# Altium schematic sheet MCU_Control.SchDoc
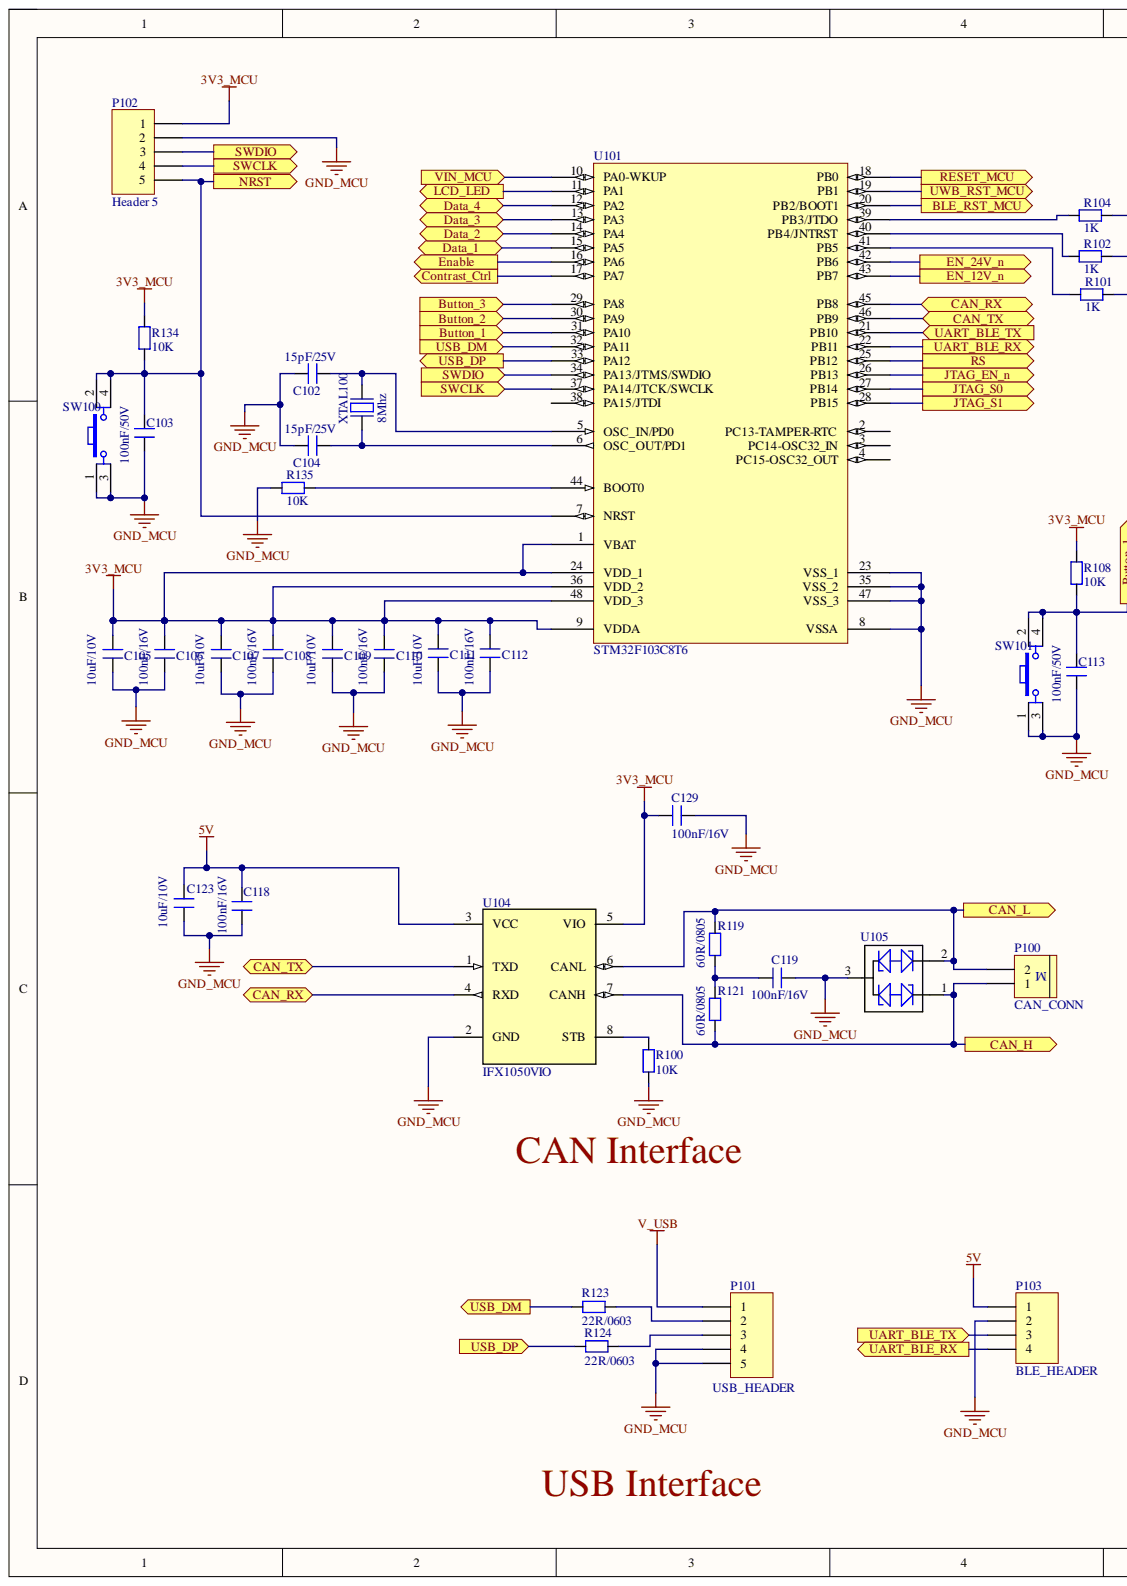
[diagram p0: MCU_Control.SchDoc, left]
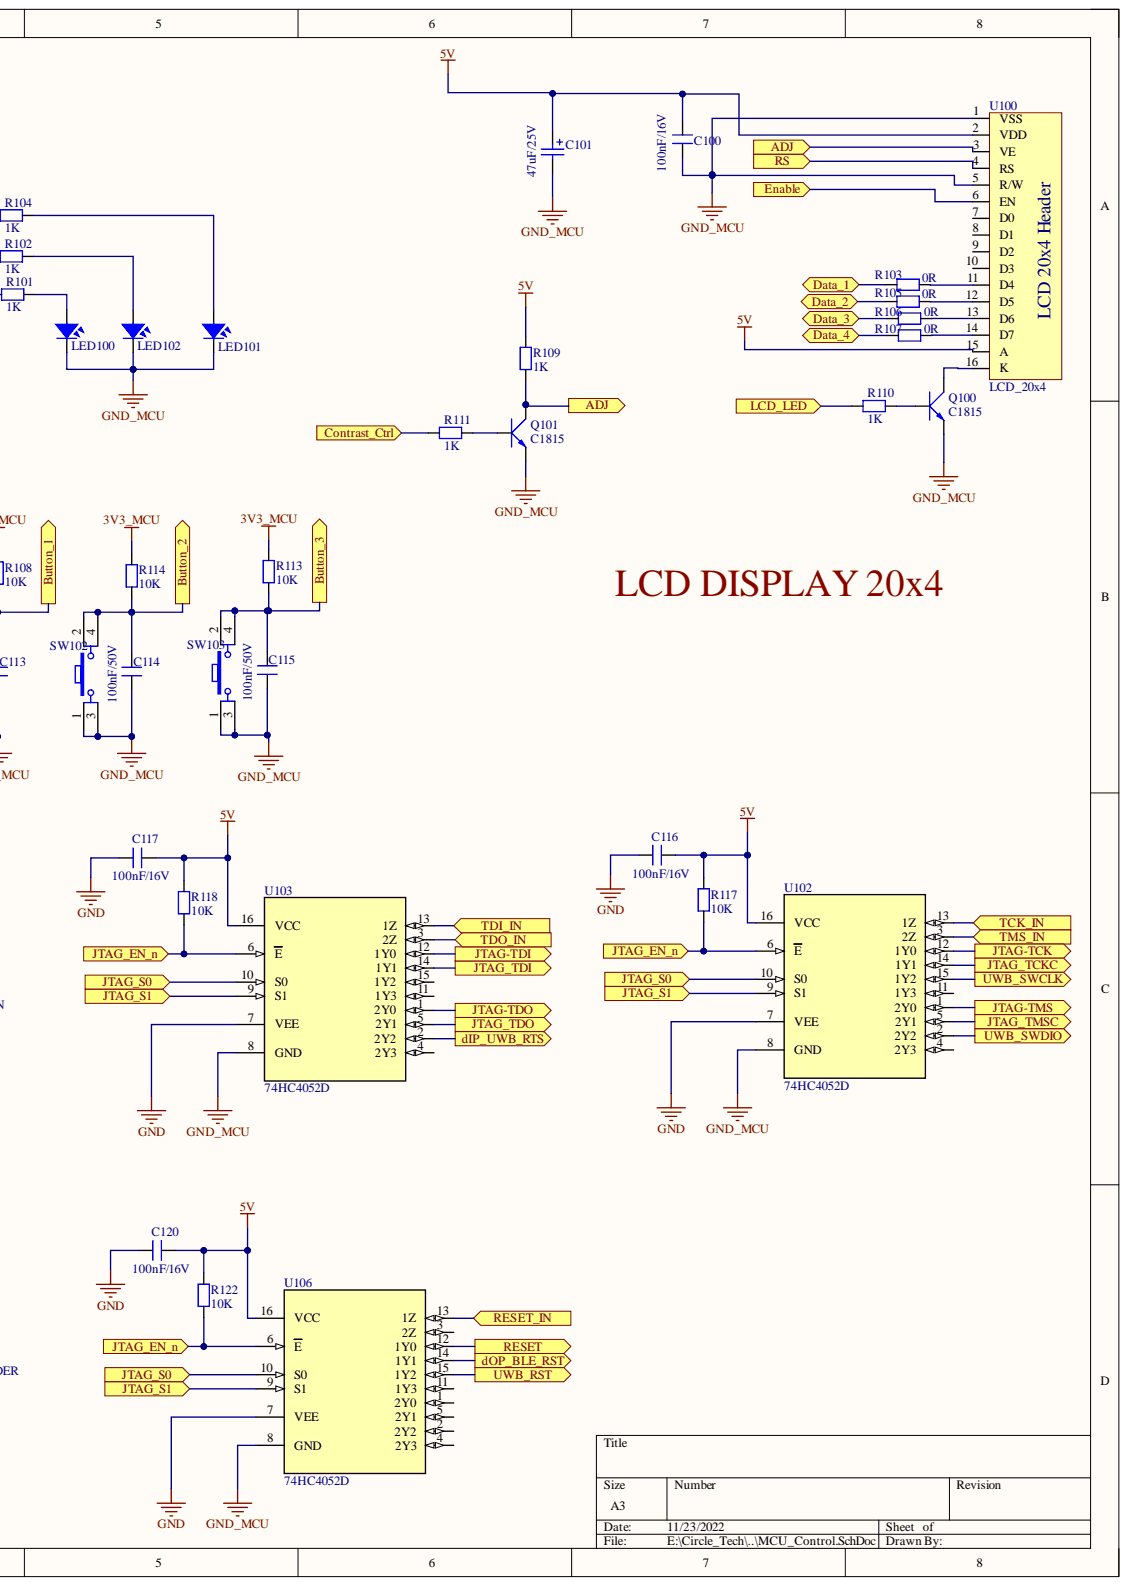
[diagram p1: MCU_Control.SchDoc, right]

## Parts
C100  value 100nF/16V  [C0603]  (hidden comment: C0603_X5R_±10%)
C101  47uF/25V  [SMD_ALU_CAP_D6.3H5.5]
C102  value 15pF/25V  [C0603]  (hidden comment: C0603_X5R_±10%)
C103  value 100nF/50V  [C0603]  (hidden comment: C0603_X5R_±10%)
C104  value 15pF/25V  [C0603]  (hidden comment: C0603_X5R_±10%)
C105  value 10uF/10V  [C0805]  (hidden comment: C0805 X5R ±10%)
C106  value 100nF/16V  [C0603]  (hidden comment: C0603_X5R_±10%)
C107  value 10uF/10V  [C0805]  (hidden comment: C0805 X5R ±10%)
C108  value 100nF/16V  [C0603]  (hidden comment: C0603_X5R_±10%)
C109  value 10uF/10V  [C0805]  (hidden comment: C0805 X5R ±10%)
C110  value 100nF/16V  [C0603]  (hidden comment: C0603_X5R_±10%)
C111  value 10uF/10V  [C0805]  (hidden comment: C0805 X5R ±10%)
C112  value 100nF/16V  [C0603]  (hidden comment: C0603_X5R_±10%)
C113  value 100nF/50V  [C0603]  (hidden comment: C0603_X5R_±10%)
C114  value 100nF/50V  [C0603]  (hidden comment: C0603_X5R_±10%)
C115  value 100nF/50V  [C0603]  (hidden comment: C0603_X5R_±10%)
C116  value 100nF/16V  [C0603]  (hidden comment: C0603_X5R_±10%)
C117  value 100nF/16V  [C0603]  (hidden comment: C0603_X5R_±10%)
C118  value 100nF/16V  [C0603]  (hidden comment: C0603_X5R_±10%)
C119  value 100nF/16V  [C0603]  (hidden comment: C0603_X5R_±10%)
C120  value 100nF/16V  [C0603]  (hidden comment: C0603_X5R_±10%)
C123  value 10uF/10V  [C0805]  (hidden comment: C0805 X5R ±10%)
C129  value 100nF/16V  [C0603]  (hidden comment: C0603_X5R_±10%)
LED100  LED SMD  [SM-LED-0805-Red]  (value not drawn)
LED101  LED SMD  [SM-LED-0805-Blue]  (value not drawn)
LED102  LED SMD  [SM-LED-0805-Green]  (value not drawn)
P100  CAN_CONN  [Medium - Header 2]
P101  USB_HEADER  [HDR1X5]
P102  Header 5  [HDR1X5]
P103  BLE_HEADER  [HDR1X4]
Q100  C1815  [SOT23B]
Q101  C1815  [SOT23B]
R100  value 10K  [R0603]  (hidden comment: Value)
R101  value 1K  [R0603]  (hidden comment: Value)
R102  value 1K  [R0603]  (hidden comment: Value)
R103  value 0R  [R0603]  (hidden comment: Value)
R104  value 1K  [R0603]  (hidden comment: Value)
R105  value 0R  [R0603]  (hidden comment: Value)
R106  value 0R  [R0603]  (hidden comment: Value)
R107  value 0R  [R0603]  (hidden comment: Value)
R108  value 10K  [R0603]  (hidden comment: Value)
R109  value 1K  [R0603]  (hidden comment: Value)
R110  value 1K  [R0603]  (hidden comment: Value)
R111  value 1K  [R0603]  (hidden comment: Value)
R113  value 10K  [R0603]  (hidden comment: Value)
R114  value 10K  [R0603]  (hidden comment: Value)
R117  value 10K  [R0603]  (hidden comment: Value)
R118  value 10K  [R0603]  (hidden comment: Value)
R119  60R/0805  [R0805]
R121  60R/0805  [R0805]
R122  value 10K  [R0603]  (hidden comment: Value)
R123  value 22R/0603  [R0603]
R124  value 22R/0603  [R0603]
R134  value 10K  [R0603]  (hidden comment: Value)
R135  value 10K  [R0603]  (hidden comment: Value)
SW100  SW  [SW4]
SW101  SW  [SW4]
SW102  SW  [SW4]
SW103  SW  [SW4]
U100  LCD_20x4  [LCD_20x4]
U101  STM32F103C8T6  [LQFP48_L]
U102  74HC4052D  [P-SOIC-16]
U103  74HC4052D  [P-SOIC-16]
U104  IFX1050VIO  [SOIC-8]
U105  Value  [SOT23]  (value not drawn)
U106  74HC4052D  [P-SOIC-16]
XTAL100  8Mhz  [Crystal_3225]

## Ports
ADJ, BLE_RST_MCU, Button_1, Button_2, Button_3, CAN_H, CAN_L, CAN_RX, CAN_TX, Contrast_Ctrl, Data_1, Data_2, Data_3, Data_4, dIP_UWB_RTS, dOP_BLE_RST, EN_12V_n, EN_24V_n, Enable, JTAG-TCK, JTAG-TDI, JTAG-TDO, JTAG-TMS, JTAG_EN_n, JTAG_S0, JTAG_S1, JTAG_TCKC, JTAG_TDI, JTAG_TDO, JTAG_TMSC, LCD_LED, NRST, RESET, RESET_IN, RESET_MCU, RS, SWCLK, SWDIO, TCK_IN, TDI_IN, TDO_IN, TMS_IN, UART_BLE_RX, UART_BLE_TX, USB_DM, USB_DP, UWB_RST, UWB_RST_MCU, UWB_SWCLK, UWB_SWDIO, VIN_MCU

## Nets
3V3_MCU: C105.2 C106.2 C107.2 C108.2 C109.2 C110.2 C111.2 C112.2 C129.2 P102.1 R108.2 R113.2 R114.2 R134.2 U101.1(VBAT) U101.9(VDDA) U101.24(VDD_1) U101.36(VDD_2) U101.48(VDD_3) U104.5(VIO)
5V: C100.2 C101.1 C116.1 C117.1 C118.2 C120.1 C123.2 P103.1 R109.2 R117.2 R118.2 R122.2 U100.2(VDD) U100.15(A) U102.16(VCC) U103.16(VCC) U104.3(VCC) U106.16(VCC)
GND: C116.2 C117.2 C120.2 U102.7(VEE) U103.7(VEE) U106.7(VEE)
GND_MCU: C100.1 C101.2 C102.2 C103.1 C104.2 C105.1 C106.1 C107.1 C108.1 C109.1 C110.1 C111.1 C112.1 C113.1 C114.1 C115.1 C118.1 C119.1 C123.1 C129.1 LED100.2 LED101.2 LED102.2 P101.4 P101.5 P102.2 P103.2 Q100.1 Q101.1 R100.1 R135.1 SW100.1 SW100.3 SW101.1 SW101.3 SW102.1 SW102.3 SW103.1 SW103.3 U100.1(VSS) U100.5(R/W) U101.8(VSSA) U101.23(VSS_1) U101.35(VSS_2) U101.47(VSS_3) U102.8(GND) U103.8(GND) U104.2(GND) U105.3 U106.8(GND)
V_USB: P101.1
ADJ: Q101.2 R109.1 U100.3(VE) ; port ADJ
BLE_RST_MCU: U101.20(PB2/BOOT1) ; port BLE_RST_MCU
Button_1: C113.2 R108.1 SW101.2 SW101.4 U101.31(PA10) ; port Button_1
Button_2: C114.2 R114.1 SW102.2 SW102.4 U101.30(PA9) ; port Button_2
Button_3: C115.2 R113.1 SW103.2 SW103.4 U101.29(PA8) ; port Button_3
CAN_H: P100.1 R121.1 U104.7(CANH) U105.1 ; port CAN_H
CAN_L: P100.2 R119.2 U104.6(CANL) U105.2 ; port CAN_L
CAN_RX: U101.45(PB8) U104.4(RXD) ; port CAN_RX
CAN_TX: U101.46(PB9) U104.1(TXD) ; port CAN_TX
Contrast_Ctrl: R111.1 U101.17(PA7) ; port Contrast_Ctrl
Data_1: R103.1 U101.15(PA5) ; port Data_1
Data_2: R105.1 U101.14(PA4) ; port Data_2
Data_3: R106.1 U101.13(PA3) ; port Data_3
Data_4: R107.1 U101.12(PA2) ; port Data_4
dIP_UWB_RTS: U103.2(2Y2) ; port dIP_UWB_RTS
dOP_BLE_RST: U106.14(1Y1) ; port dOP_BLE_RST
EN_12V_n: U101.43(PB7) ; port EN_12V_n
EN_24V_n: U101.42(PB6) ; port EN_24V_n
Enable: U100.6(EN) U101.16(PA6) ; port Enable
JTAG-TCK: U102.12(1Y0) ; port JTAG-TCK
JTAG-TMS: U102.1(2Y0) ; port JTAG-TMS
JTAG_EN_n: R117.1 R118.1 R122.1 U101.26(PB13) U102.6(E) U103.6(E) U106.6(E) ; port JTAG_EN_n
JTAG_S0: U101.27(PB14) U102.10(S0) U103.10(S0) U106.10(S0) ; port JTAG_S0
JTAG_S1: U101.28(PB15) U102.9(S1) U103.9(S1) U106.9(S1) ; port JTAG_S1
JTAG_TCKC: U102.14(1Y1) ; port JTAG_TCKC
JTAG_TDO: U103.5(2Y1) ; port JTAG_TDO
JTAG_TMSC: U102.5(2Y1) ; port JTAG_TMSC
LCD_LED: R110.1 U101.11(PA1) ; port LCD_LED
NRST: C103.2 P102.5 R134.1 SW100.2 SW100.4 U101.7(NRST) ; port NRST
RESET: U106.12(1Y0) ; port RESET
RESET_IN: U106.13(1Z) ; port RESET_IN
RESET_MCU: U101.18(PB0) ; port RESET_MCU
RS: U100.4(RS) U101.25(PB12) ; port RS
SWCLK: P102.4 U101.37(PA14/JTCK/SWCLK) ; port SWCLK
SWDIO: P102.3 U101.34(PA13/JTMS/SWDIO) ; port SWDIO
TCK_IN: U102.13(1Z) ; port TCK_IN
TDI_IN: U103.13(1Z) ; port TDI_IN
TDO_IN: U103.3(2Z) ; port TDO_IN
TMS_IN: U102.3(2Z) ; port TMS_IN
UART_BLE_RX: P103.4 U101.22(PB11) ; port UART_BLE_RX
UART_BLE_TX: P103.3 U101.21(PB10) ; port UART_BLE_TX
USB_DM: R123.1 U101.32(PA11) ; port USB_DM
USB_DP: R124.1 U101.33(PA12) ; port USB_DP
UWB_RST: U106.15(1Y2) ; port UWB_RST
UWB_RST_MCU: U101.19(PB1) ; port UWB_RST_MCU
UWB_SWCLK: U102.15(1Y2) ; port UWB_SWCLK
UWB_SWDIO: U102.2(2Y2) ; port UWB_SWDIO
VIN_MCU: U101.10(PA0-WKUP) ; port VIN_MCU
NetC102_1: C102.1 U101.5(OSC_IN/PD0) XTAL100.3
NetC104_1: C104.1 U101.6(OSC_OUT/PD1) XTAL100.1
NetC119_2: C119.2 R119.1 R121.2
NetLED100_1: LED100.1 R101.2
NetLED101_1: LED101.1 R104.2
NetLED102_1: LED102.1 R102.2
NetP101_2: P101.2 R123.2
NetP101_3: P101.3 R124.2
NetQ100_2: Q100.2 U100.16(K)
NetQ100_3: Q100.3 R110.2
NetQ101_3: Q101.3 R111.2
NetR100_2: R100.2 U104.8(STB)
NetR101_1: R101.1 U101.41(PB5)
NetR102_1: R102.1 U101.40(PB4/JNTRST)
NetR103_2: R103.2 U100.11(D4)
NetR104_1: R104.1 U101.39(PB3/JTDO)
NetR105_2: R105.2 U100.12(D5)
NetR106_2: R106.2 U100.13(D6)
NetR107_2: R107.2 U100.14(D7)
NetR135_2: R135.2 U101.44(BOOT0)
NetU103_1: U103.1(2Y0)
NetU103_12: U103.12(1Y0)
NetU103_14: U103.14(1Y1)

Not connected: U100 7(D0) 8(D1) 9(D2) 10(D3); U101 2(PC13-TAMPER-RTC) 3(PC14-OSC32_IN) 4(PC15-OSC32_OUT) 38(PA15/JTDI); U102 4(2Y3) 11(1Y3); U103 4(2Y3) 11(1Y3) 15(1Y2); U106 1(2Y0) 2(2Y2) 3(2Z) 4(2Y3) 5(2Y1) 11(1Y3)

## Library data of the parts
C100 C102-C104 C106 C108 C110 C112-C120 C129 = C0603_X5R_±10%: SMD Ceramic Capacitor 0603 X5R ±10%; Component Kind: Standard; Component Type: Passive; Package: C0603; Library Name: Daniel_Lib.IntLib
C101 = SMD_ALU_CAP: SMD Aluminum Electrolytic Capacitor; Manufacturer: Wurth Elektronik; Manufacturer Part Number: 865230140001; Capacitance: 22uF; Capacitance Tolerance: +/-20%; Dissipation Factor: 32%; Endurance: 5000h; Leakage Current: 3uA; Rated Voltage: 6.3VDC; Ripple Current: 22mA; Technical Reference: ASDB055220M6R3DVCTAE000; Component Kind: Standard; ComponentLink1Description: Manufacturer; ComponentLink1URL: http://www.we-online.de; ComponentLink2Description: Datasheet; ComponentLink2URL: https://www.we-online.com/catalog/datasheet/865230140001.pdf; Diameter: 4mm; Height: 5.5mm; Mounting Technology: SMT; Operating Temperature: -40 to +105degC; Packaging: Tape and Reel; Published Date: October 2020; Library Name: Daniel_Lib.IntLib
C105 C107 C109 C111 C123 = C0805 X5R ±10%: SMD Ceramic Capacitor 0805 X5R ±10%; Component Kind: Standard; Component Type: Passive; Package: C0805; Library Name: Daniel_Lib.IntLib
LED100-LED102 = LED-SMD-0805: Component Kind: Standard; Library Name: syhaunguyen.IntLib
P100 = Header 2 - M: Header, 2-Pin, Medium; Component Kind: Standard; LatestRevisionDate: 17-Jul-2002; LatestRevisionNote: Re-released for DXP Platform.; Library Name: NBBLib 14-03-2012.IntLib; Signal Integrity: Connector; Publisher: Altium Limited
P101 P102 = Header 5: Header, 5-Pin; Component Kind: Standard; LatestRevisionDate: 17-Jul-2002; LatestRevisionNote: Re-released for DXP Platform.; Library Name: NBBLib 14-03-2012.IntLib; Signal Integrity: Connector; Publisher: Altium Limited
P103 = Header 4: Header, 4-Pin; Component Kind: Standard; LatestRevisionDate: 17-Jul-2002; LatestRevisionNote: Re-released for DXP Platform.; Library Name: NBBLib 14-03-2012.IntLib; Signal Integrity: Connector; Publisher: Altium Limited
Q100 Q101 = C1815: NPN 600mW, ECB; Component Kind: Standard; Value: C1815; Library Name: syhaunguyen.IntLib
R100-R111 R113 R114 R117 R118 R122-R124 R134 R135 = R0603: SMD Resistor 0603 0.1W 1%; Manufacturer: Uniohm; Component Kind: Standard; Component Type: Passive; Package: R0603; Library Name: Daniel_Lib.IntLib
R119 R121 = R0805: Resistor SMD 0805 0.125W; Component Kind: Standard; Library Name: Daniel_Lib.IntLib
SW100-SW103 = SW4: Switch button 4 pin; Value: Button chip 4 pin; Component Kind: Standard; Library Name: syhaunguyen.IntLib
U100 = LCD_20x4: LCD 20x4; Component Kind: Standard; Library Name: Daniel_Lib.IntLib
U101 = STM32F103C8T6: STM32 ARM-based 32-bit MCU with 64 Kbytes Flash, 48-pin LQFP, Industrial Temperature; Component Kind: Standard; Library Name: TrieuLIB.IntLib
U102 U103 U106 = 74HC4052D: 2 Circuit IC Switch 4:1 150Ohm 16-SO; MF: Nexperia USA; MP: 74HC4052D,652; Availability: Good; Component Kind: Standard; DigiKey-Purchase-URL: https://snapeda.com/shop?store=DigiKey&id=12544; Library Name: IC.IntLib; Mouser-Purchase-URL: https://snapeda.com/shop?store=Mouser&id=12544; Package: SOIC-16 NXP Semiconductors; Price: None; SnapEDA_Link: https://www.snapeda.com/parts/74HC4052D,652/Nexperia%20USA%20Inc./view-part/12544/?ref=snap
U104 = IFX1050VIO: 1/1 Transceiver Half CANbus 8-SO; MF: NXP USA; MP: TJA1040; Availability: Unavailable; Component Kind: Standard; Library Name: IC.IntLib; Package: SOIC-8 NXP USA; Price: None; SnapEDA_Link: https://www.snapeda.com/parts/TJA1040/NXP%20USA%20Inc./view-part/563351/?ref=snap
U105 = PESD_SOT23: Low capacitance double bidirectional ESD protection diodes  in SOT23; Component Kind: Standard; Library Name: Daniel_Lib.IntLib
XTAL100 = Crystal_3225_SMD: Crystal SMD 3225; MF: Vishay Vitramon; Availability: Unavailable; Component Kind: Standard; Package: 3216 Vishay Vitramon; Library Name: Daniel_Lib.IntLib
